# Altium schematic sheet CaINv2.0.SchDoc
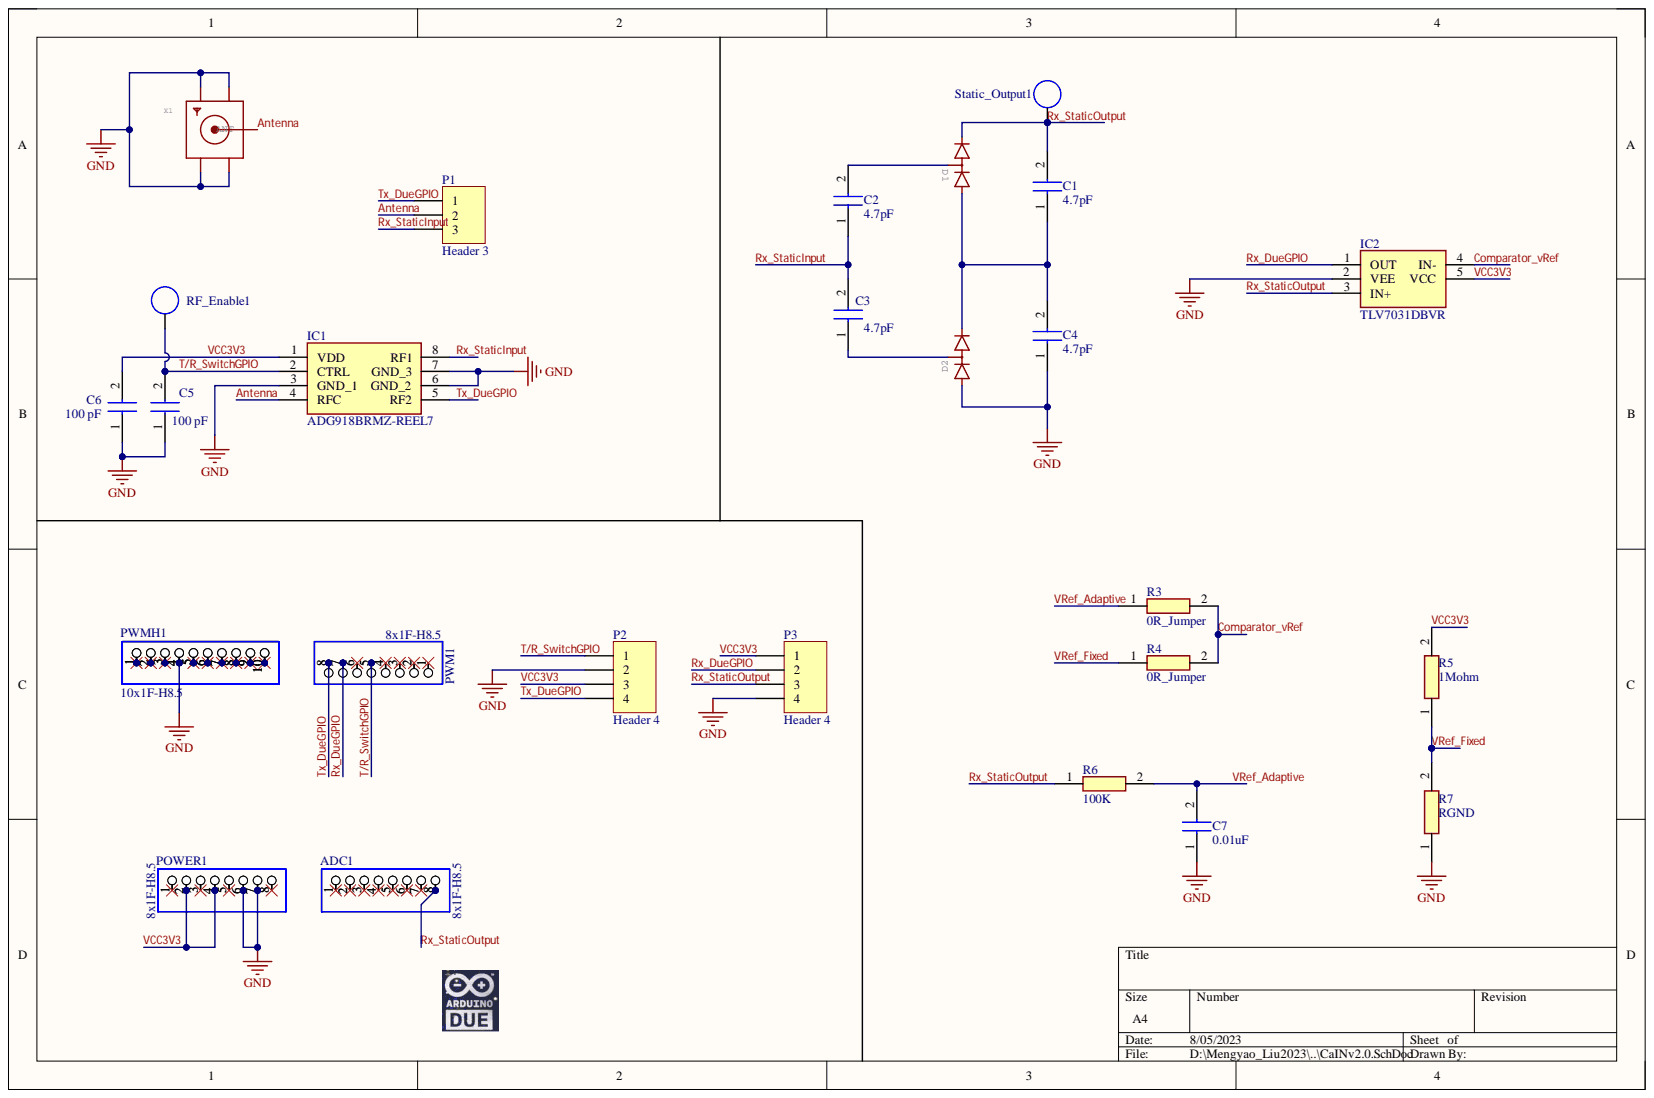
[diagram p0: CaINv2.0.SchDoc]

## Parts
ADC1  8x1F-H8.5  [1X08]
C1  4.7pF  [CAPC2012X145N]
C2  4.7pF  [CAPC2012X145N]
C3  4.7pF  [CAPC2012X145N]
C4  4.7pF  [CAPC2012X145N]
C5  100 pF  [CAPC2012X145N]
C6  100 pF  [CAPC2012X145N]
C7  0.01uF  [CAPC2012X145N]
D1  RB706F-40FHT106  [SOT23]  (value not drawn)
D2  RB706F-40FHT106  [SOT23]  (value not drawn)
IC1  ADG918BRMZ-REEL7  [SOP65P490X110-8N]
IC2  TLV7031DBVR  [SOT95P280X145-5N]
P1  Header 3  [HDR1X3]
P2  Header 4  [HDR1X4]
P3  Header 4  [HDR1X4]
POWER1  8x1F-H8.5  [1X08]
PWM1  8x1F-H8.5  [1X08]
PWMH1  10x1F-H8.5  [1X10]
R3  0R_Jumper  [RESC2013X84N]
R4  0R_Jumper  [RESC2013X84N]
R5  1Mohm  [RESC2012X50N]
R6  100K  [RESC2012X50N]
R7  RGND  [RESC2012X50N]
RF_Enable1  (no value)  [TestPoint]  (no BOM)
Static_Output1  TP  [TestPoint]  (value not drawn)  (no BOM)
X1  SMACONNECTOR_EDGE  [SMA_EDGELAUNCH]  (value not drawn)

## Nets
GND: C4.1 C5.1 C6.1 C7.1 D2.1 IC1.3(GND_1) IC1.6(GND_2) IC1.7(GND_3) IC2.2(VEE) P2.2 P3.4 POWER1.6 POWER1.7 PWMH1.4 R7.1 X1.GND@1 X1.GND@2 X1.GND@3 X1.GND@4
Antenna: IC1.4(RFC) P1.2 X1.P(ANT)
Comparator_vRef: IC2.4(IN-) R3.2 R4.2
Rx_DueGPIO: IC2.1(OUT) P3.2 PWM1.7
Rx_StaticInput: C2.1 C3.2 IC1.8(RF1) P1.3
Rx_StaticOutput: ADC1.8 C1.2 D1.2 IC2.3(IN+) P3.3 R6.1 Static_Output1.TP
T/R_SwitchGPIO: C5.2 IC1.2(CTRL) P2.1 PWM1.5 RF_Enable1.TP
Tx_DueGPIO: IC1.5(RF2) P1.1 P2.4 PWM1.8
VCC3V3: C6.2 IC1.1(VDD) IC2.5(VCC) P2.3 P3.1 POWER1.2 POWER1.4 R5.2
VRef_Adaptive: C7.2 R3.1 R6.2
VRef_Fixed: R4.1 R5.1 R7.2
NetC1_1: C1.1 C4.2 D1.1 D2.2
NetC2_2: C2.2 D1.3
NetC3_1: C3.1 D2.3

Not connected: ADC1 1 2 3 4 5 6 7; POWER1 1 3 5 8; PWM1 1 2 3 4 6; PWMH1 1 2 3 5 6 7 8 9 10

## Library data of the parts
ADC1 POWER1 PWM1 = PINHD-1X8: Component Kind: Standard; Library Name: Arduino_Due.IntLib
C1-C6 = VJ0805A101JXBMC: Capacitor; Manufacturer_Name: Vishay; Arrow Part Number: VJ0805A101JXBMC; Arrow Price/Stock: https://www.arrow.com/en/products/vj0805a101jxbmc/vishay?region=nac; Component Kind: Standard; Datasheet Link: http://www.vishay.com/docs/45226/vj31xrohsautomlcc.pdf; Height: 1.45mm; Library Name: SamacSys.SchLib; Manufacturer_Part_Number: VJ0805A101JXBMC; Mouser Part Number: 77-VJ0805A101JXBMC; Mouser Price/Stock: https://www.mouser.co.uk/ProductDetail/Vishay-Vitramon/VJ0805A101JXBMC?qs=DyYCzLTSlfCgHy2DX6Cz6w%3D%3D
C7 = VJ0805Y103KXBAC: Capacitor; Manufacturer_Name: Vishay; Arrow Part Number: VJ0805Y103KXBAC; Arrow Price/Stock: https://www.arrow.com/en/products/vj0805y103kxbac/vishay?region=nac; Component Kind: Standard; Datasheet Link: http://www.vishay.com/docs/45226/vj31xrohsautomlcc.pdf; Height: 1.45mm; Library Name: SamacSys.SchLib; Manufacturer_Part_Number: VJ0805Y103KXBAC; Mouser Part Number: 77-VJ08Y100V103K; Mouser Price/Stock: https://www.mouser.co.uk/ProductDetail/Vishay-Vitramon/VJ0805Y103KXBAC?qs=IRuQNBqMOckF0HeddYSaNg%3D%3D
D1 D2 = DIODE_2CACA-SOT23: 2 Diodes - Cathode-Anode|Cathode-Anode DIODE_2CACA-SOT23; GateName_1: -B1; POPULARITY: 1; SymbolName_1: KA2KA; Component Kind: Standard; DeviceName: SOT23; DeviceSetName: DIODE_2CACA-; Library Name: RF_EHv1.1.IntLib; LibraryName: diode
IC1 = ADG918BRMZ-REEL7: Integrated Circuit; Manufacturer_Name: Analog Devices; Arrow Part Number: ADG918BRMZ-REEL7; Arrow Price/Stock: https://www.arrow.com/en/products/adg918brmz-reel7/analog-devices; Component Kind: Standard; Datasheet Link: https://www.analog.com/media/en/technical-documentation/data-sheets/ADG918_919.pdf; Height: 1.1mm; Library Name: SamacSys.SchLib; Manufacturer_Part_Number: ADG918BRMZ-REEL7; Mouser Part Number: 584-ADG918BRMZ-R7; Mouser Price/Stock: https://www.mouser.co.uk/ProductDetail/Analog-Devices/ADG918BRMZ-REEL7?qs=BpaRKvA4VqEay0U7Dc17vw%3D%3D
IC2 = TLV7031DBVR: Integrated Circuit; Manufacturer_Name: Texas Instruments; Arrow Part Number: TLV7031DBVR; Arrow Price/Stock: https://www.arrow.com/en/products/tlv7031dbvr/texas-instruments?region=nac; Component Kind: Standard; Datasheet Link: http://www.ti.com/lit/gpn/TLV7031; Height: 1.45mm; Library Name: SamacSys.SchLib; Manufacturer_Part_Number: TLV7031DBVR; Mouser Part Number: 595-TLV7031DBVR; Mouser Price/Stock: https://www.mouser.co.uk/ProductDetail/Texas-Instruments/TLV7031DBVR?qs=EBDBlbfErPzxbC0zg598sw%3D%3D
P1 = Header 3: Header, 3-Pin; Component Kind: Standard; LatestRevisionDate: 17-Jul-2002; LatestRevisionNote: Re-released for DXP Platform.; Library Name: 常用元件集成库.IntLib; Publisher: Altium Limited
P2 P3 = Header 4: Header, 4-Pin; Component Kind: Standard; LatestRevisionDate: 17-Jul-2002; LatestRevisionNote: Re-released for DXP Platform.; Library Name: 常用元件集成库.IntLib; Publisher: Altium Limited
PWMH1 = PINHD-1X10: Component Kind: Standard; Library Name: Arduino_Due.IntLib
R3 R4 = WSL080500000ZEA9: Resistor 0ohm_0805; Manufacturer_Name: Vishay; Arrow Part Number: WSL080500000ZEA9; Arrow Price/Stock: https://www.arrow.com/en/products/wsl080500000zea9/vishay?region=nac; Component Kind: Standard; Datasheet Link: https://ad.componentsearchengine.com/Datasheets/2/WSL080500000ZEA9.pdf; Height: 0.838mm; Library Name: SamacSys.SchLib; Manufacturer_Part_Number: WSL080500000ZEA9; Mouser Part Number: 71-WSL080500000ZEA9; Mouser Price/Stock: https://www.mouser.co.uk/ProductDetail/Vishay-Dale/WSL080500000ZEA9?qs=f1l5vAGoKgsImKS87N8TmQ%3D%3D
R5-R7 = RCS0805100KFKEA: Resistor; Manufacturer_Name: Vishay; Arrow Part Number: RCS0805100KFKEA; Arrow Price/Stock: https://www.arrow.com/en/products/rcs0805100kfkea/vishay?region=nac; Component Kind: Standard; Datasheet Link: https://componentsearchengine.com/Datasheets/2/RCS0805100KFKEA.pdf; Height: 0.5mm; Library Name: SamacSys.SchLib; Manufacturer_Part_Number: RCS0805100KFKEA; Mouser Part Number: 71-RCS0805100KFKEA; Mouser Price/Stock: https://www.mouser.co.uk/ProductDetail/Vishay-Dale/RCS0805100KFKEA?qs=NKmfXavxMay%252BH0mbX9hKBw%3D%3D
RF_Enable1 Static_Output1 = TestPoint
  RF_Enable1: Library Name: revibe_z.SchLib
  Static_Output1: Library Name: ReVibe_Z.IntLib
X1 = SMACONNECTOR_EDGE: SMA Connector   90掳 DIP SMA Connector, 50 Ohm (4UConnector: 07259); DeviceName: _EDGE; GateName_1: G$1; Component Kind: Standard; DeviceSetName: SMACONNECTOR; Library Name: RF_EHv1.1.IntLib; LibraryName: adafruit; SymbolName_1: SMACONNECTOR
